# Altium schematic sheet AFE_to_ADC_to_ESP.SchDoc(AFE_to_ADC_to_ESP)
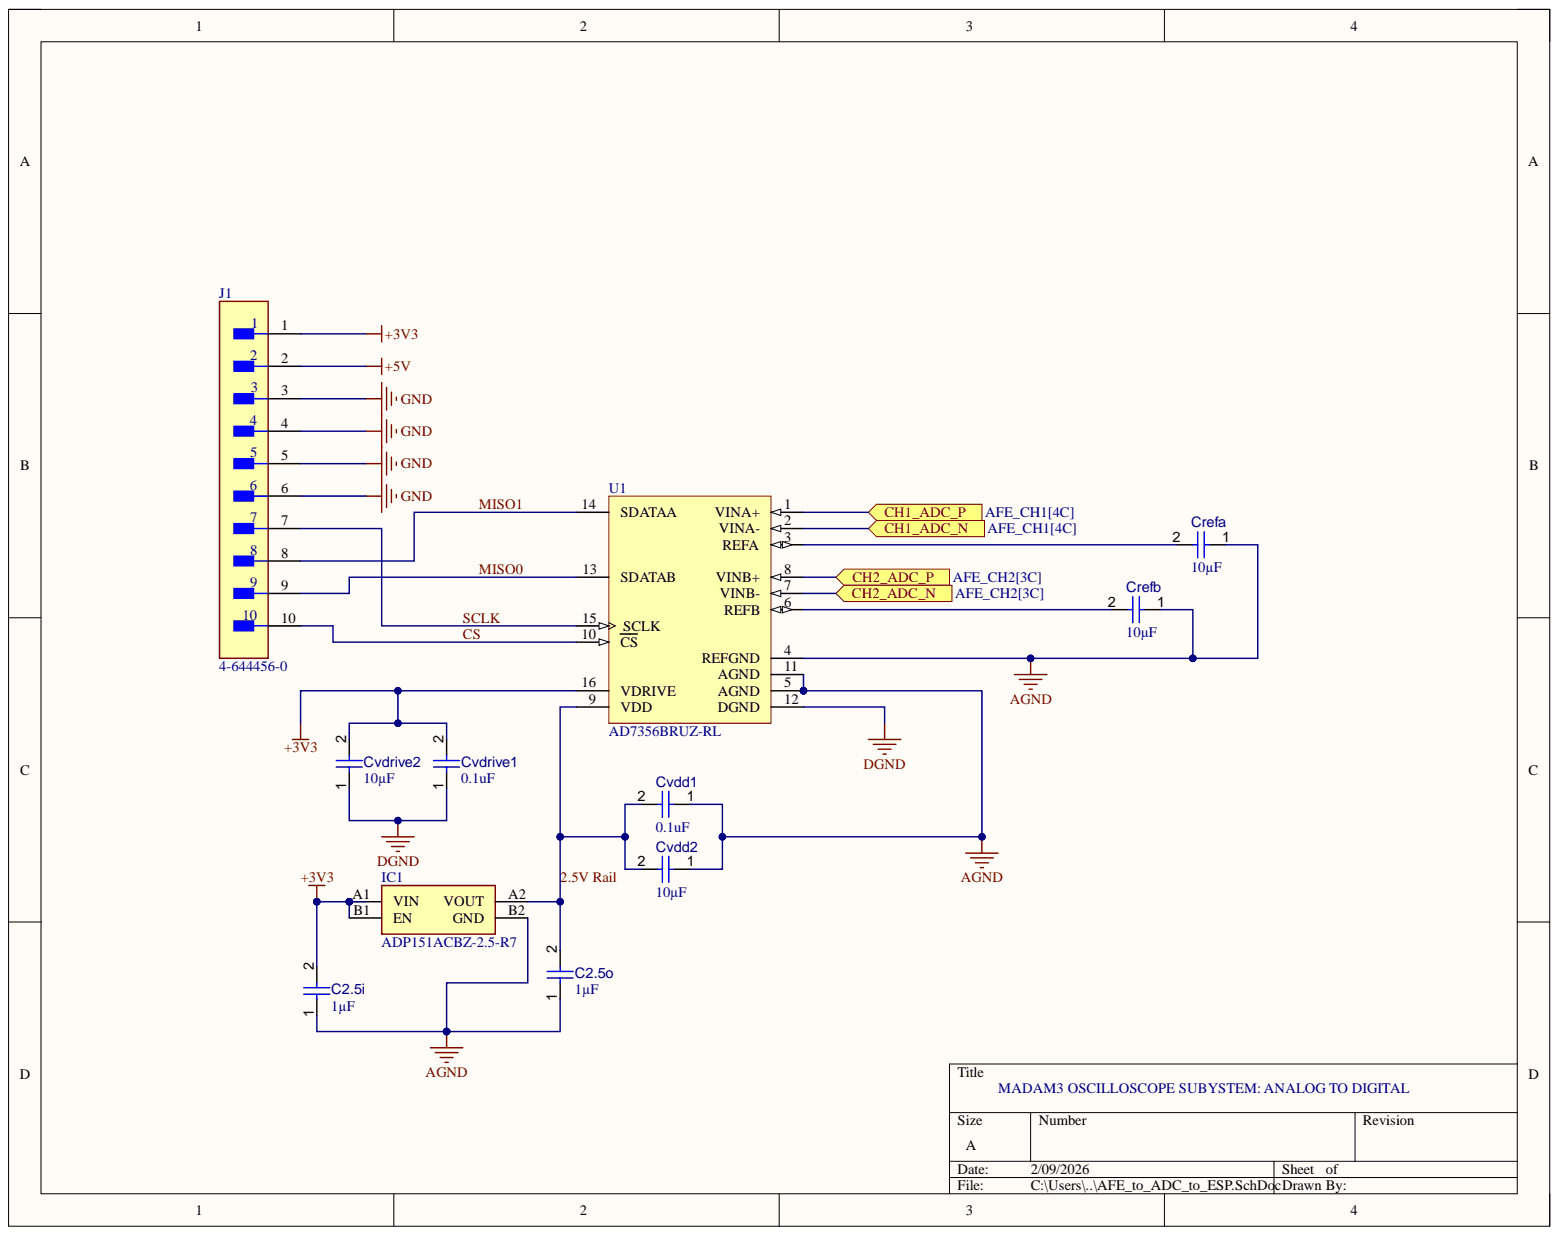
[diagram p0: AFE_to_ADC_to_ESP.SchDoc]

## Parts
C2.5i  value 1µF  [CAPC1608X94X35NL15T15]  (hidden comment: Capacitor 1 uF +/- 10% 16 V 0603)
C2.5o  value 1µF  [CAPC1608X94X35NL15T15]  (hidden comment: Capacitor 1 uF +/- 10% 16 V 0603)
Crefa  value 10µF  [CAPC2013X135X45NL10T25]  (hidden comment: Capacitor 10 uF +/- 10% 16 V 0805)
Crefb  value 10µF  [CAPC2013X135X45NL10T25]  (hidden comment: Capacitor 10 uF +/- 10% 16 V 0805)
Cvdd1  value 0.1uF  [CAPC1608X90X40NL10T20]  (hidden comment: Capacitor 100 nF +/-10% 50 V 0603)
Cvdd2  value 10µF  [CAPC2013X135X45NL10T25]  (hidden comment: Capacitor 10 uF +/- 10% 16 V 0805)
Cvdrive1  value 0.1uF  [CAPC1608X90X40NL10T20]  (hidden comment: Capacitor 100 nF +/-10% 50 V 0603)
Cvdrive2  value 10µF  [CAPC2013X135X45NL10T25]  (hidden comment: Capacitor 10 uF +/- 10% 16 V 0805)
IC1  ADP151ACBZ-2.5-R7  [BGA4C40P2X2_76X76X66]
J1  4-644456-0  [FP-4-644456-0-MFG]
U1  AD7356BRUZ-RL  [RU-16_N]

## Ports
CH1_ADC_N, CH1_ADC_P, CH2_ADC_N, CH2_ADC_P

## Nets
+3V3: C2.5i.2 Cvdrive1.2 Cvdrive2.2 IC1.A1(VIN) IC1.B1(EN) J1.1 U1.16(VDRIVE)
+5V: J1.2
AGND: C2.5i.1 C2.5o.1 Crefa.1 Crefb.1 Cvdd1.1 Cvdd2.1 IC1.B2(GND) U1.4(REFGND) U1.5(AGND) U1.11(AGND)
DGND: Cvdrive1.1 Cvdrive2.1 U1.12(DGND)
GND: J1.3 J1.4 J1.5 J1.6
2.5V Rail: C2.5o.2 Cvdd1.2 Cvdd2.2 IC1.A2(VOUT) U1.9(VDD)
CH1_ADC_N: U1.2(VINA-) ; port CH1_ADC_N
CH1_ADC_P: U1.1(VINA+) ; port CH1_ADC_P
CH2_ADC_N: U1.7(VINB-) ; port CH2_ADC_N
CH2_ADC_P: U1.8(VINB+) ; port CH2_ADC_P
CS: J1.10 U1.10(CS)
MISO0: J1.9 U1.13(SDATAB)
MISO1: J1.8 U1.14(SDATAA)
SCLK: J1.7 U1.15(SCLK)
NetCrefa_2: Crefa.2 U1.3(REFA)
NetCrefb_2: Crefb.2 U1.6(REFB)

## Library data of the parts
C2.5i C2.5o = CMP-001-00003-6: Chip Capacitor, 1 uF, +/- 10%, 16 V, 0603 (1608 Metric); Capacitor Type: Ceramic; Voltage Rating: 16V; Case/Package: 0603; Component Kind: Standard; Max Operating Temperature: 125°C; Min Operating Temperature: -55°C; Mounting Technology: SM; Pins: 2; RoHS Compliant: True; Tolerance: 10%; Library Name: Amz Amz
Crefa Crefb Cvdd2 Cvdrive2 = CMP-001-00015-6: Chip Capacitor, 10 uF, +/- 10%, 16 V, 0805 (2012 Metric); Capacitor Type: Ceramic; Voltage Rating: 16V; Case/Package: 0805; Component Kind: Standard; Max Operating Temperature: 85°C; Min Operating Temperature: -55°C; Mounting Technology: SM; Pins: 2; RoHS Compliant: True; Tolerance: 10%; Library Name: Amz Amz
Cvdd1 Cvdrive1 = CMP-001-00017-6: Chip Capacitor, 100 nF, +/- 10%, 50 V, 0603 (1608 Metric); Capacitor Type: Ceramic; Voltage Rating: 50V; Case/Package: 0603; Component Kind: Standard; Max Operating Temperature: 125°C; Min Operating Temperature: -55°C; Mounting Technology: SM; Pins: 2; RoHS Compliant: True; Tolerance: 10%; Library Name: Amz Amz
IC1 = ADP151ACBZ-2.5-R7: Integrated Circuit; Manufacturer_Name: Analog Devices; Arrow Part Number: ADP151ACBZ-2.5-R7; Arrow Price/Stock: null?region=nac; Component Kind: Standard; Datasheet Link: http://componentsearchengine.com/Datasheets/1/ADP151ACBZ-2.5-R7.pdf; Manufacturer_Part_Number: ADP151ACBZ-2.5-R7; Mouser Part Number: 584-ADP151ACBZ-2.5R7; Mouser Price/Stock: https://www.mouser.co.uk/ProductDetail/Analog-Devices/ADP151ACBZ-2.5-R7?qs=WIvQP4zGangTI5VHmrYcyg%3D%3D
J1 = CMP-03369-000038-1: CONN HEADER VERT 10POS 2.54MM; Manufacturer: TE Connectivity; Conn Gender: Male; Conn Orientation: Vertical; Current Rating: 5A; Number Of Contacts: 10; Voltage Rating: 250V; Automotive: No; Category: Conn; Component Kind: Standard; ComponentLink2Description: Datasheet; ComponentLink2URL: https://www.te.com/commerce/DocumentDelivery/DDEController?Action=showdoc&DocId=Data+Sheet%7F82056_MTA%7F0818%7Fpdf%7FEnglish%7FENG_DS_82056_MTA_0818.pdf%7F4-644456-0; Contact Material: Tin; Device Class L1: Connectors; Device Class L2: Headers and Wire Housings; Device Class L3: unset; Digikey Description: CONN HEADER VERT 10POS 2.54MM; Footprint Url: https://www.te.com/commerce/DocumentDelivery/DDEController?Action=showdoc&DocId=Customer+Drawing%7F644456%7FD2%7Fpdf%7FEnglish%7FENG_CD_644456_D2.pdf%7F4-644456-0; Height: 0.408inch; Lead Free: Yes; Library Name: Altium Content Vault; Manufacturer Part Number: 4-644456-0; Mouser Description: Headers & Wire Housings NARROW FLT HDR 10P Straight Post; Number Of Rows: 1; Octopart: https://octopart.com/4-644456-0-te+connectivity-39514173; Package: 4-644456-0; Pitch: 2.54mm; RoHS: TRUE; Temperature: -55°C to +105°C; Temperature Range High: +105°C; Temperature Range Low: -55°C
U1 = CMP-0313-01897-2: Dual 12-Bit SAR Analog-to-Digital Converter with Serial, SPI Interface, Simultaneous Sampling, 5MSPS, -40 to +85 degC, RU-16, Pb-Free, Reel; Generic Part Number: AD7356; Manufacturer: Analog Devices; Channels: 2; Interface: Ser, SPI; Resolution (Bits): 12; Throughput Rate (SPS): 5M; Code_JEDEC: MO-153-AB; Component Kind: Standard; ComponentLink1Description: Manufacturer URL; ComponentLink1URL: http://www.analog.com/en/index.html; ComponentLink2Description: Datasheet; ComponentLink2URL: http://www.analog.com/static/imported-files/data_sheets/AD7356.pdf; ComponentLink3Description: Package Specification; ComponentLink3URL: http://www.analog.com/static/imported-files/packages/36244347913743854010197452789RU16.pdf; ComponentLink4Description: Product URL; ComponentLink4URL: http://www.analog.com/en/analog-to-digital-converters/ad-converters/ad7356/products/product.html; DatasheetVersion: Rev.A; Library Name: Altium Content Vault; Mounting Technology: Surface Mount; PackageDescription: 16-Lead Thin Shrink Small Outline Package [TSSOP]; PackageReference: RU-16; PackageVersion: Nov-2002; Packing: Reel; PartNumber: AD7356BRUZ-RL; RoHS: Y
